# Altium schematic sheet JTAG_connector.SchDoc(JTAG_connector)
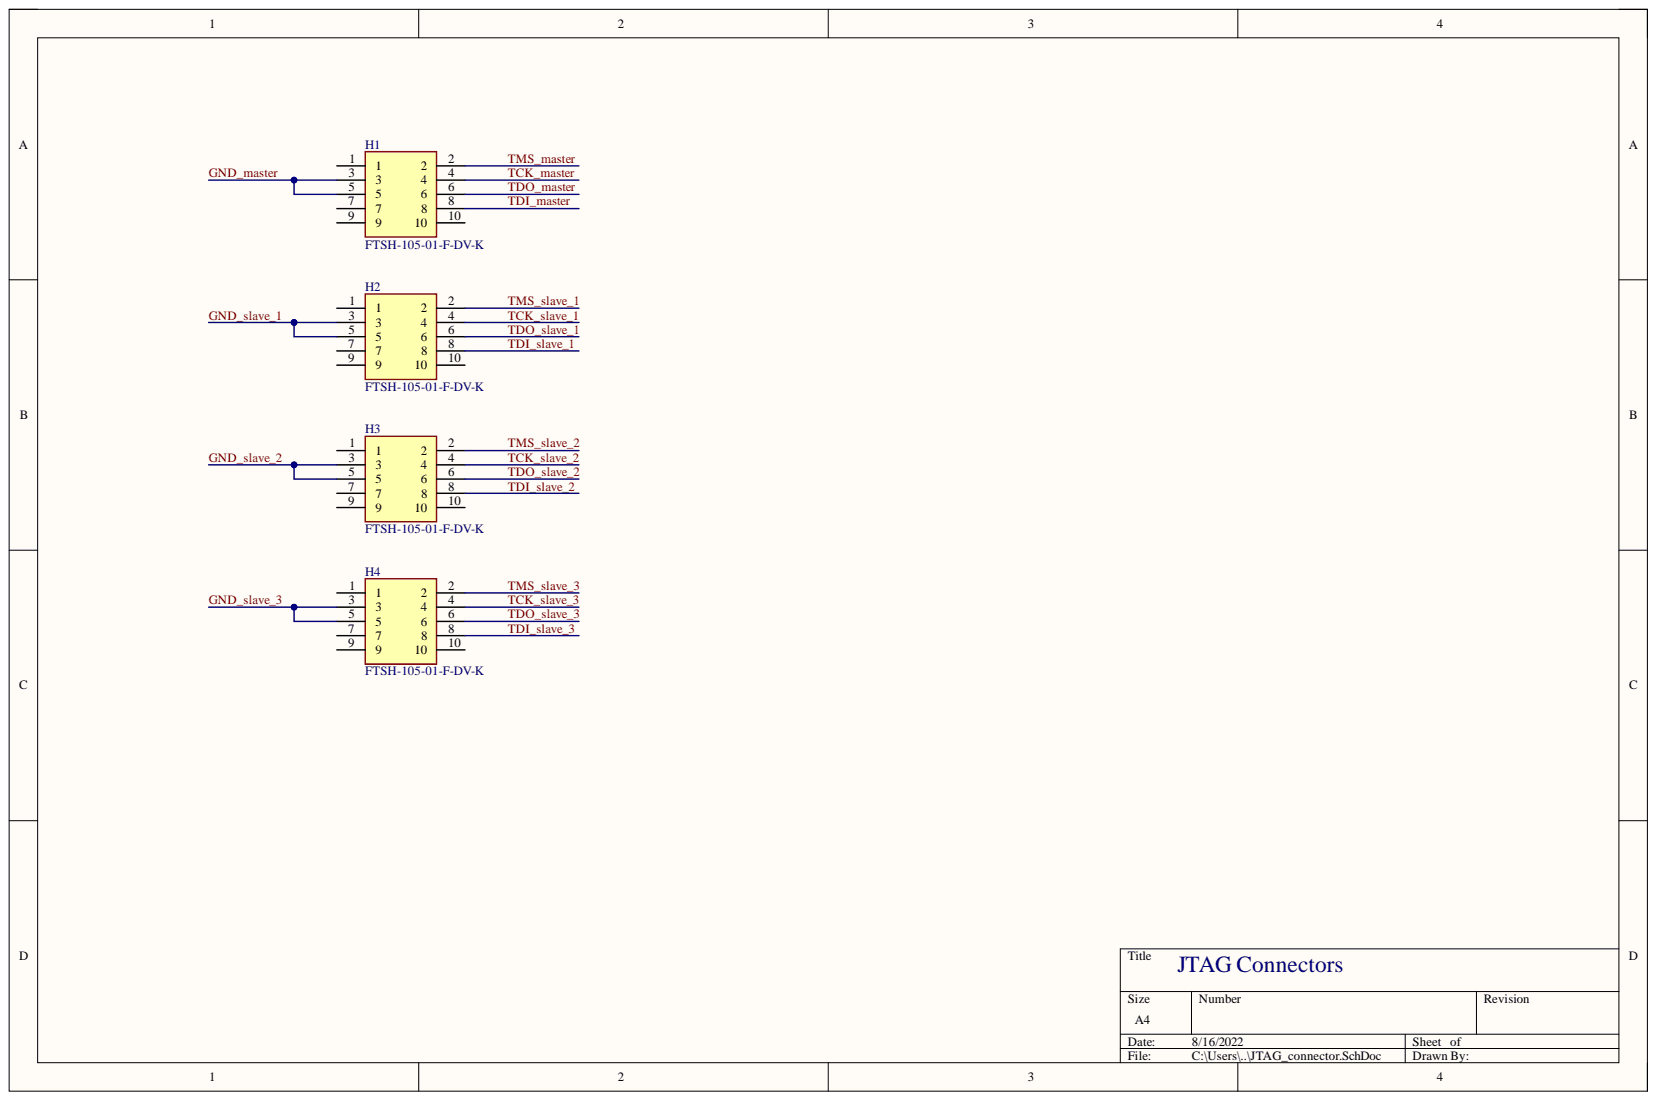
[diagram p0: JTAG_connector.SchDoc]

## Parts
H1  FTSH-105-01-F-DV-K  [FTSH10501FDVK]
H2  FTSH-105-01-F-DV-K  [FTSH10501FDVK]
H3  FTSH-105-01-F-DV-K  [FTSH10501FDVK]
H4  FTSH-105-01-F-DV-K  [FTSH10501FDVK]

## Nets
GND_master: H1.3 H1.5
GND_slave_1: H2.3 H2.5
GND_slave_2: H3.3 H3.5
GND_slave_3: H4.3 H4.5
TCK_master: H1.4
TCK_slave_1: H2.4
TCK_slave_2: H3.4
TCK_slave_3: H4.4
TDI_master: H1.8
TDI_slave_1: H2.8
TDI_slave_2: H3.8
TDI_slave_3: H4.8
TDO_master: H1.6
TDO_slave_1: H2.6
TDO_slave_2: H3.6
TDO_slave_3: H4.6
TMS_master: H1.2
TMS_slave_1: H2.2
TMS_slave_2: H3.2
TMS_slave_3: H4.2

Not connected: H1 1 7 9 10; H2 1 7 9 10; H3 1 7 9 10; H4 1 7 9 10

## Library data of the parts
H1-H4 = FTSH-105-01-F-DV-K: Connector; Manufacturer_Name: SAMTEC; Component Kind: Standard; Datasheet Link: http://suddendocs.samtec.com/prints/ftsh-1xx-xx-xxx-dv-xxx-xxx-mkt.pdf; Height: 6.25mm; Library Name: FTSH-105-01-F-DV-K.SchLib; Manufacturer_Part_Number: FTSH-105-01-F-DV-K
